# Altium schematic sheet MCU.SchDoc(MCU)
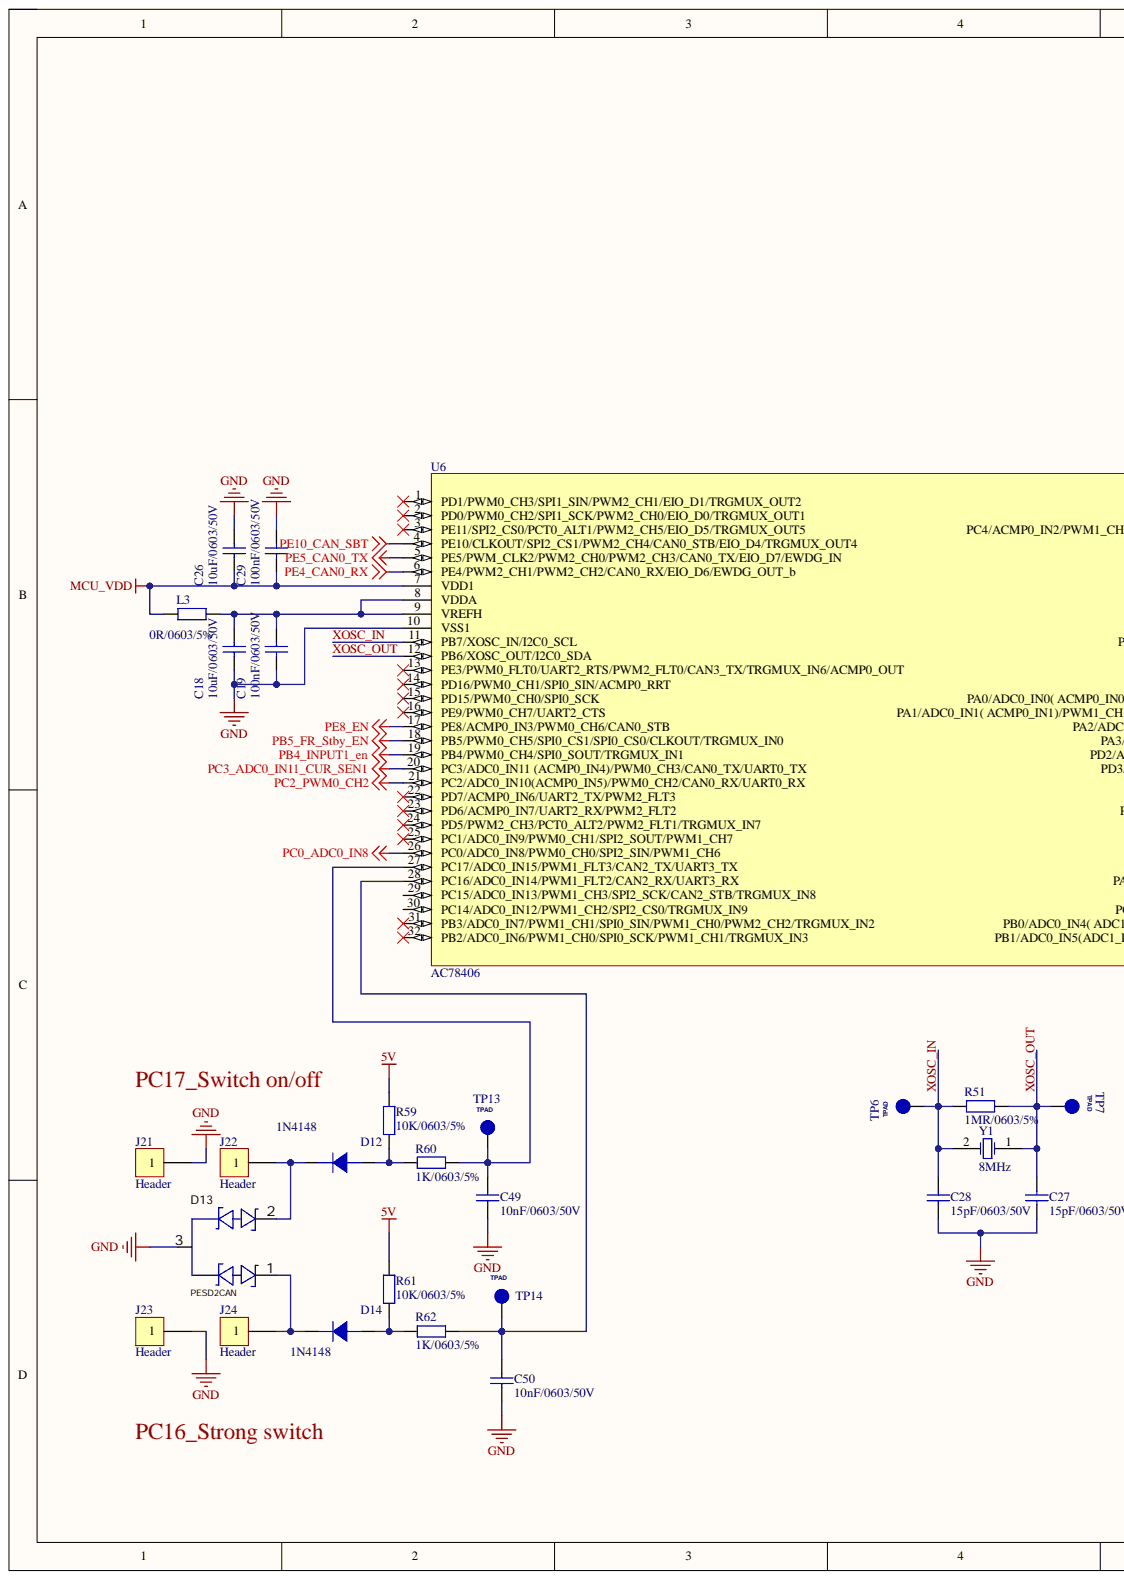
[diagram p0: MCU.SchDoc, left]
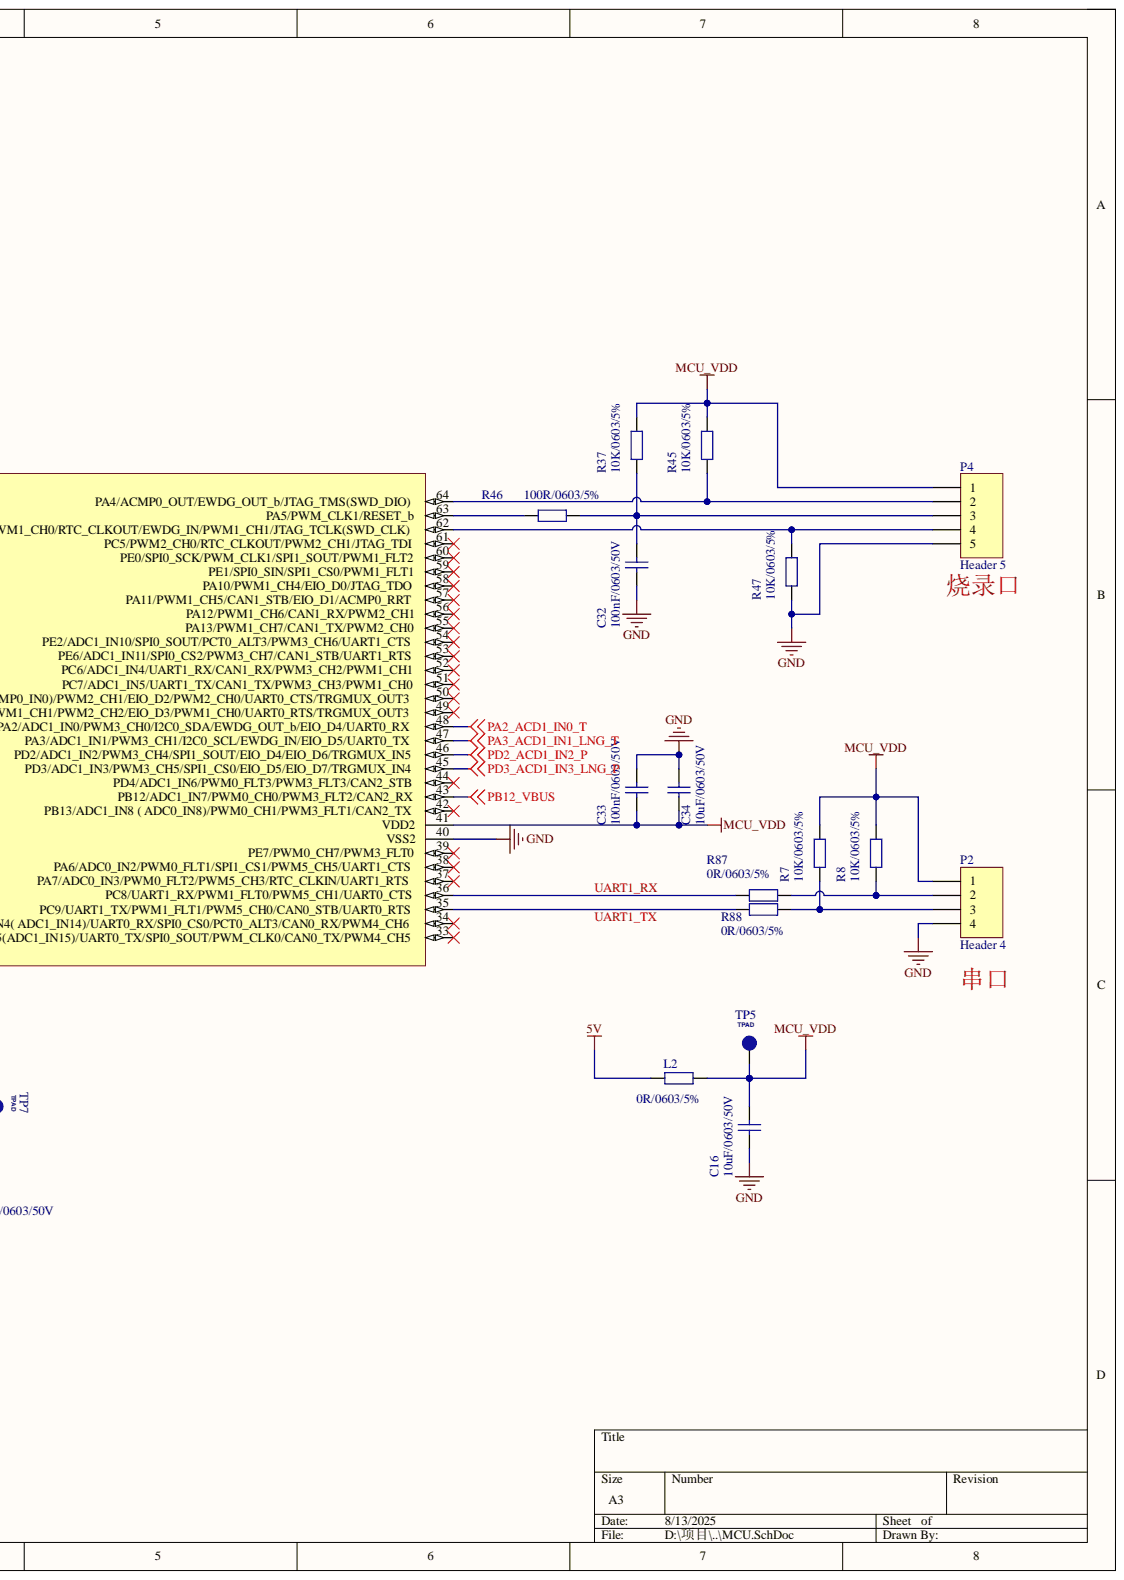
[diagram p1: MCU.SchDoc, right]

## Parts
C16  10uF/0603/50V  [RC0603]
C18  10uF/0603/50V  [RC0603]
C19  100nF/0603/50V  [RC0603]
C26  10uF/0603/50V  [RC0603]
C27  15pF/0603/50V  [RC0603]
C28  15pF/0603/50V  [RC0603]
C29  100nF/0603/50V  [RC0603]
C32  100nF/0603/50V  [RC0603]
C33  100nF/0603/50V  [RC0603]
C34  10uF/0603/50V  [RC0603]
C49  10nF/0603/50V  [RC0603]
C50  10nF/0603/50V  [RC0603]
D12  1N4148  [SOD-123]
D13  PESD2CAN  [SOT-23]
D14  1N4148  [SOD-123]
J21  Header  [J]
J22  Header  [J]
J23  Header  [J]
J24  Header  [J]
L2  0R/0603/5%  [RC0603]
L3  0R/0603/5%  [RC0603]
P2  Header 4  [HDR1X4]
P4  Header 5  [HDR1X5]
R7  10K/0603/5%  [RC0603]
R8  10K/0603/5%  [RC0603]
R37  10K/0603/5%  [RC0603]
R45  10K/0603/5%  [RC0603]
R46  100R/0603/5%  [RC0603]
R47  10K/0603/5%  [RC0603]
R51  1MR/0603/5%  [RC0603]
R59  10K/0603/5%  [RC0603]
R60  1K/0603/5%  [RC0603]
R61  10K/0603/5%  [RC0603]
R62  1K/0603/5%  [RC0603]
R87  0R/0603/5%  [RC0603]
R88  0R/0603/5%  [RC0603]
TP5  NOTE: TPAD  [TP]  (hidden comment: TPAD_030)
TP6  NOTE: TPAD  [TP]  (hidden comment: TPAD_030)
TP7  NOTE: TPAD  [TP]  (hidden comment: TPAD_030)
TP13  NOTE: TPAD  [TP]  (hidden comment: TPAD_030)
TP14  NOTE: TPAD  [TP]  (hidden comment: TPAD_030)
U6  AC78406  [LQFP-64]
Y1  8MHz  [SMD5032 - duplicate]

## Nets
5V: L2.1 R59.1 R61.1
GND: C16.1 C18.1 C19.1 C26.1 C27.2 C28.2 C29.1 C32.1 C33.1 C34.1 C49.2 C50.2 D13.3 J21.1 J23.1 P2.4 P4.5 R47.2 U6.10(VSS1) U6.40(VSS2)
MCU_VDD: C16.2 C26.2 C29.2 C33.2 C34.2 L2.2 L3.1 P2.1 P4.1 R7.1 R8.1 R37.1 R45.1 TP5.1 U6.7(VDD1) U6.41(VDD2)
PA2_ACD1_IN0_T: U6.48(PA2/ADC1_IN0/PWM3_CH0/I2C0_SDA/EWDG_OUT_b/EIO_D4/UART0_RX)
PA3_ACD1_IN1_LNG_T: U6.47(PA3/ADC1_IN1/PWM3_CH1/I2C0_SCL/EWDG_IN/EIO_D5/UART0_TX)
PB4_INPUT1_en: U6.19(PB4/PWM0_CH4/SPI0_SOUT/TRGMUX_IN1)
PB5_FR_Stby_EN: U6.18(PB5/PWM0_CH5/SPI0_CS1/SPI0_CS0/CLKOUT/TRGMUX_IN0)
PB12_VBUS: U6.43(PB12/ADC1_IN7/PWM0_CH0/PWM3_FLT2/CAN2_RX)
PC0_ADC0_IN8: U6.26(PC0/ADC0_IN8/PWM0_CH0/SPI2_SIN/PWM1_CH6)
PC2_PWM0_CH2: U6.21(PC2/ADC0_IN10(ACMP0_IN5)/PWM0_CH2/CAN0_RX/UART0_RX)
PC3_ADC0_IN11_CUR_SEN1: U6.20(PC3/ADC0_IN11_(ACMP0_IN4)/PWM0_CH3/CAN0_TX/UART0_TX)
PD2_ACD1_IN2_P: U6.46(PD2/ADC1_IN2/PWM3_CH4/SPI1_SOUT/EIO_D4/EIO_D6/TRGMUX_IN5)
PD3_ACD1_IN3_LNG_P: U6.45(PD3/ADC1_IN3/PWM3_CH5/SPI1_CS0/EIO_D5/EIO_D7/TRGMUX_IN4)
PE4_CAN0_RX: U6.6(PE4/PWM2_CH1/PWM2_CH2/CAN0_RX/EIO_D6/EWDG_OUT_b)
PE5_CAN0_TX: U6.5(PE5/PWM_CLK2/PWM2_CH0/PWM2_CH3/CAN0_TX/EIO_D7/EWDG_IN)
PE8_EN: U6.17(PE8/ACMP0_IN3/PWM0_CH6/CAN0_STB)
PE10_CAN_SBT: U6.4(PE10/CLKOUT/SPI2_CS1/PWM2_CH4/CAN0_STB/EIO_D4/TRGMUX_OUT4)
XOSC_IN: C28.1 R51.1 TP6.1 U6.11(PB7/XOSC_IN/I2C0_SCL) Y1.2
XOSC_OUT: C27.1 R51.2 TP7.1 U6.12(PB6/XOSC_OUT/I2C0_SDA) Y1.1
NetC18_2: C18.2 C19.2 L3.2 U6.8(VDDA) U6.9(VREFH)
NetC32_2: C32.2 P4.3 R37.2 R46.2
NetC49_1: C49.1 R60.2 TP13.1 U6.27(PC17/ADC0_IN15/PWM1_FLT3/CAN2_TX/UART3_TX)
NetC50_1: C50.1 R62.2 TP14.1 U6.28(PC16/ADC0_IN14/PWM1_FLT2/CAN2_RX/UART3_RX)
NetD12_1: D12.1 R59.2 R60.1
NetD12_2: D12.2 D13.2 J22.1
NetD13_1: D13.1 D14.2 J24.1
NetD14_1: D14.1 R61.2 R62.1
NetP2_2: P2.2 R8.2 R87.2
NetP2_3: P2.3 R7.2 R88.2
NetP4_2: P4.2 R45.2 U6.64(PA4/ACMP0_OUT/EWDG_OUT_b/JTAG_TMS(SWD_DIO))
NetP4_4: P4.4 R47.1 U6.62(PC4/ACMP0_IN2/PWM1_CH0/RTC_CLKOUT/EWDG_IN/PWM1_CH1/JTAG_TCLK(SWD_CLK))
NetR46_1: R46.1 U6.63(PA5/PWM_CLK1/RESET_b)
NetR87_1: R87.1 U6.36(PC8/UART1_RX/PWM1_FLT0/PWM5_CH1/UART0_CTS)
NetR88_1: R88.1 U6.35(PC9/UART1_TX/PWM1_FLT1/PWM5_CH0/CAN0_STB/UART0_RTS)

Not connected: U6 1(PD1/PWM0_CH3/SPI1_SIN/PWM2_CH1/EIO_D1/TRGMUX_OUT2) 2(PD0/PWM0_CH2/SPI1_SCK/PWM2_CH0/EIO_D0/TRGMUX_OUT1) 3(PE11/SPI2_CS0/PCT0_ALT1/PWM2_CH5/EIO_D5/TRGMUX_OUT5) 13(PE3/PWM0_FLT0/UART2_RTS/PWM2_FLT0/CAN3_TX/TRGMUX_IN6/ACMP0_OUT) 14(PD16/PWM0_CH1/SPI0_SIN/ACMP0_RRT) 15(PD15/PWM0_CH0/SPI0_SCK) 16(PE9/PWM0_CH7/UART2_CTS) 22(PD7/ACMP0_IN6/UART2_TX/PWM2_FLT3) 23(PD6/ACMP0_IN7/UART2_RX/PWM2_FLT2) 24(PD5/PWM2_CH3/PCT0_ALT2/PWM2_FLT1/TRGMUX_IN7) 25(PC1/ADC0_IN9/PWM0_CH1/SPI2_SOUT/PWM1_CH7) 29(PC15/ADC0_IN13/PWM1_CH3/SPI2_SCK/CAN2_STB/TRGMUX_IN8) 30(PC14/ADC0_IN12/PWM1_CH2/SPI2_CS0/TRGMUX_IN9) 31(PB3/ADC0_IN7/PWM1_CH1/SPI0_SIN/PWM1_CH0/PWM2_CH2/TRGMUX_IN2) 32(PB2/ADC0_IN6/PWM1_CH0/SPI0_SCK/PWM1_CH1/TRGMUX_IN3) 33(PB1/ADC0_IN5(ADC1_IN15)/UART0_TX/SPI0_SOUT/PWM_CLK0/CAN0_TX/PWM4_CH5) 34(PB0/ADC0_IN4(_ADC1_IN14)/UART0_RX/SPI0_CS0/PCT0_ALT3/CAN0_RX/PWM4_CH6) 37(PA7/ADC0_IN3/PWM0_FLT2/PWM5_CH3/RTC_CLKIN/UART1_RTS) 38(PA6/ADC0_IN2/PWM0_FLT1/SPI1_CS1/PWM5_CH5/UART1_CTS) 39(PE7/PWM0_CH7/PWM3_FLT0) 42(PB13/ADC1_IN8_(_ADC0_IN8)/PWM0_CH1/PWM3_FLT1/CAN2_TX) 44(PD4/ADC1_IN6/PWM0_FLT3/PWM3_FLT3/CAN2_STB) 49 50(PA0/ADC0_IN0(_ACMP0_IN0)/PWM2_CH1/EIO_D2/PWM2_CH0/UART0_CTS/TRGMUX_OUT3) 51(PC7/ADC1_IN5/UART1_TX/CAN1_TX/PWM3_CH3/PWM1_CH0) 52(PC6/ADC1_IN4/UART1_RX/CAN1_RX/PWM3_CH2/PWM1_CH1) 53(PE6/ADC1_IN11/SPI0_CS2/PWM3_CH7/CAN1_STB/UART1_RTS) 54(PE2/ADC1_IN10/SPI0_SOUT/PCT0_ALT3/PWM3_CH6/UART1_CTS) 55(PA13/PWM1_CH7/CAN1_TX/PWM2_CH0) 56(PA12/PWM1_CH6/CAN1_RX/PWM2_CH1) 57(PA11/PWM1_CH5/CAN1_STB/EIO_D1/ACMP0_RRT) 58(PA10/PWM1_CH4/EIO_D0/JTAG_TDO) 59(PE1/SPI0_SIN/SPI1_CS0/PWM1_FLT1) 60(PE0/SPI0_SCK/PWM_CLK1/SPI1_SOUT/PWM1_FLT2) 61(PC5/PWM2_CH0/RTC_CLKOUT/PWM2_CH1/JTAG_TDI)

## Library data of the parts
C16 C18 C19 C26-C29 C32-C34 C49 C50 = C: 贴片电容/MLCC电容; BOM名称: 无极性贴片电容; 联系下单店铺卖家 免费帮你查找封装: 联系下单店铺卖家 免费技术指导; Component Kind: Standard; Library Name: 0.1 - 电阻-电容-电感-保险丝-晶振-晶体-磁珠-电位器-法拉电容-馈通电容.SchLib
D12 D14 = DIODE_2: PCB Footprint: SOD-123-2P85X1P8; Component Kind: Standard
D13 = PESD2CAN: Manufacturer: PROTEK; Add into BOM: yes; Breakdown Voltage: 13.3V; Device: PSM712-LF-T7; Maximum Clamping Voltage: 19V; Reverse Stand-Off Voltage (Vrwm): 12V; Type: TVS; Component Kind: Standard; Convert to PCB: yes; Datasheet: https://atta.szlcsc.com/upload/public/pdf/source/20140717/1457707154667.pdf; JLCPCB Part Class: Basic Part; LCSC Part Name: PSM712-LF-T7; Library Name: Schlib1.SchLib; Manufacturer Part: PSM712-LF-T7; Supplier: LCSC; Supplier Footprint: SOT-23; Supplier Part: C32677; Symbol: PSM712-LF-T7
L2 L3 R37 R45-R47 R51 R59-R62 R87 R88 = R: 贴片电阻; 联系下单店铺卖家 免费帮你查找封装: 联系下单店铺卖家 免费技术指导; BOM名称: 贴片电阻; Component Kind: Standard; Library Name: 0.1 - 电阻-电容-电感-保险丝-晶振-晶体-磁珠-电位器-法拉电容-馈通电容.SchLib
P2 = Header 4: Header, 4-Pin; Component Kind: Standard; LatestRevisionDate: 17-Jul-2002; LatestRevisionNote: Re-released for DXP Platform.; Library Name: Miscellaneous Connectors.IntLib; Signal Integrity: Connector; Publisher: Altium Limited
P4 = Header 5: Header, 5-Pin; Component Kind: Standard; LatestRevisionDate: 17-Jul-2002; LatestRevisionNote: Re-released for DXP Platform.; Library Name: Miscellaneous Connectors.IntLib; Signal Integrity: Connector; Publisher: Altium Limited
R7 R8 = Res2: 贴片电阻 0.1W 1KΩ ±1% 0603 RS-03K1001FT  风华; Value: 0; Component Kind: Standard; LatestRevisionNote: Re-released for DXP Platform.; Library Name: Miscellaneous Devices.IntLib; PackageReference: AXIAL-0.4; Signal Integrity: Res; Simulation: RESISTOR
TP5-TP7 TP13 TP14 = TESTLOOP: TEST POINT PAD 30MIL DIA SMT, NO PART TO ORDER; AGILE_PN: 211-76642; CAD_APPROVED: Yes; CLASS: IO; MFG_NAME01: NOTACOMPONENT; PCB Footprint: test_smd_1_2mm; ROOM: POWER SUPPLY_LR; 3D_Mechanical_Footprint_File: http://fcl.am.freescale.net/ALLEGRO/step/tpad_030.STEP; Component Kind: Standard; HEIGHT: 0.1MM; MFG_PN01: NOTACOMPONENT; ROHS: Yes
Y1 = XTAL: Crystal Oscillator; Component Kind: Standard; LatestRevisionDate: 17-Jul-2002; LatestRevisionNote: Re-released for DXP Platform.; Library Name: Miscellaneous Devices.IntLib; PackageDescription: Crystal, Thru-Hole; 2 Leads; Body 3.1 x 8.2 mm (Dia.xH); PackageReference: R38; Published: 8-Jun-2000; Simulation: XTAL; Publisher: Altium Limited
J21-J24 = Header: Component Kind: Standard; Library Name: ZZL_ZY_AC7840.SchLib
U6 = AC78406: Component Kind: Standard; Library Name: ZZL_ZY_AC7840.SchLib
